# Altium schematic sheet DC_DC.SchDoc(DC_DC)
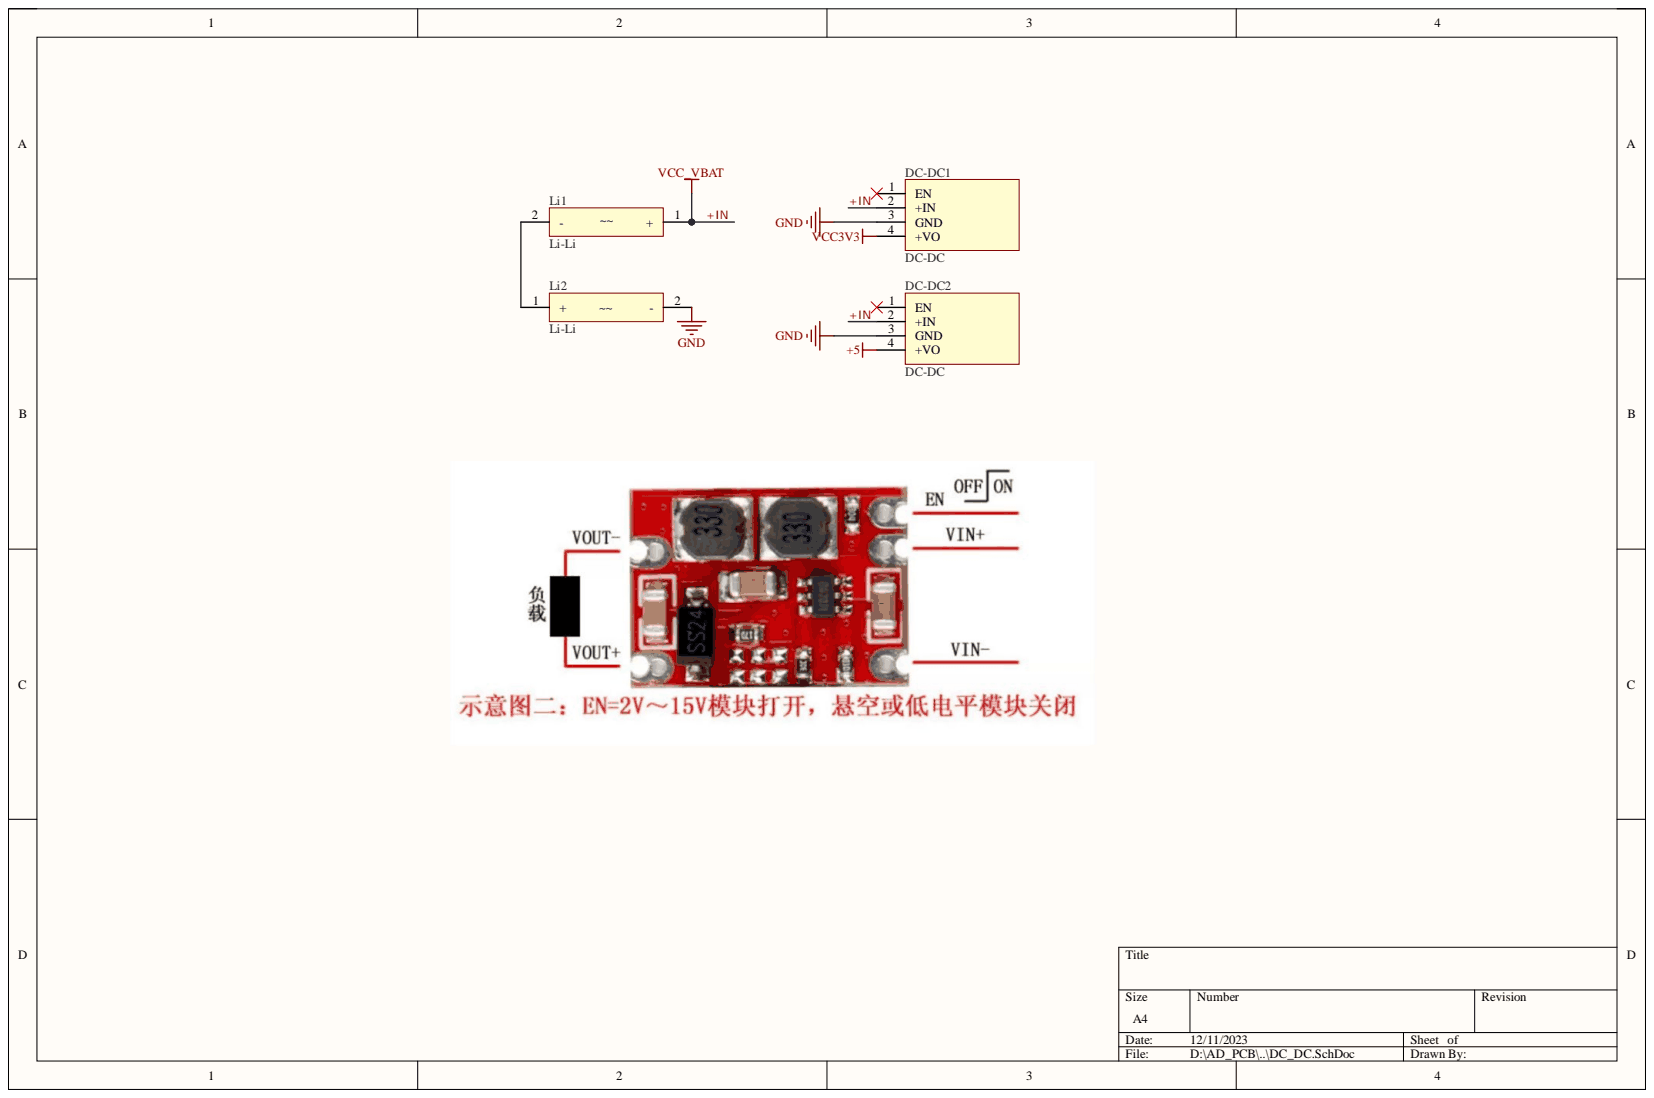
[diagram p0: DC_DC.SchDoc]

## Parts
DC-DC1  DC-DC  [DC-DC]
DC-DC2  DC-DC  [DC-DC]
Li1  Li-Li  [单个5号电池盒]
Li2  Li-Li  [单个5号电池盒]

## Nets
+5: DC-DC2.4(+VO)
GND: DC-DC1.3(GND) DC-DC2.3(GND) Li2.2
VCC3V3: DC-DC1.4(+VO)
+IN: DC-DC1.2(+IN) DC-DC2.2(+IN) Li1.1
NetLi1_2: Li1.2 Li2.1

Not connected: DC-DC1 1(EN); DC-DC2 1(EN)

## Library data of the parts
DC-DC1 DC-DC2 = DC-DC: Component Kind: Standard; Library Name: STM32_Phone.SchLib
Li1 Li2 = Li-Li: Component Kind: Standard; Library Name: STM32_Phone.SchLib
